# Altium schematic sheet Schematic Prints("All Documents",Physical)
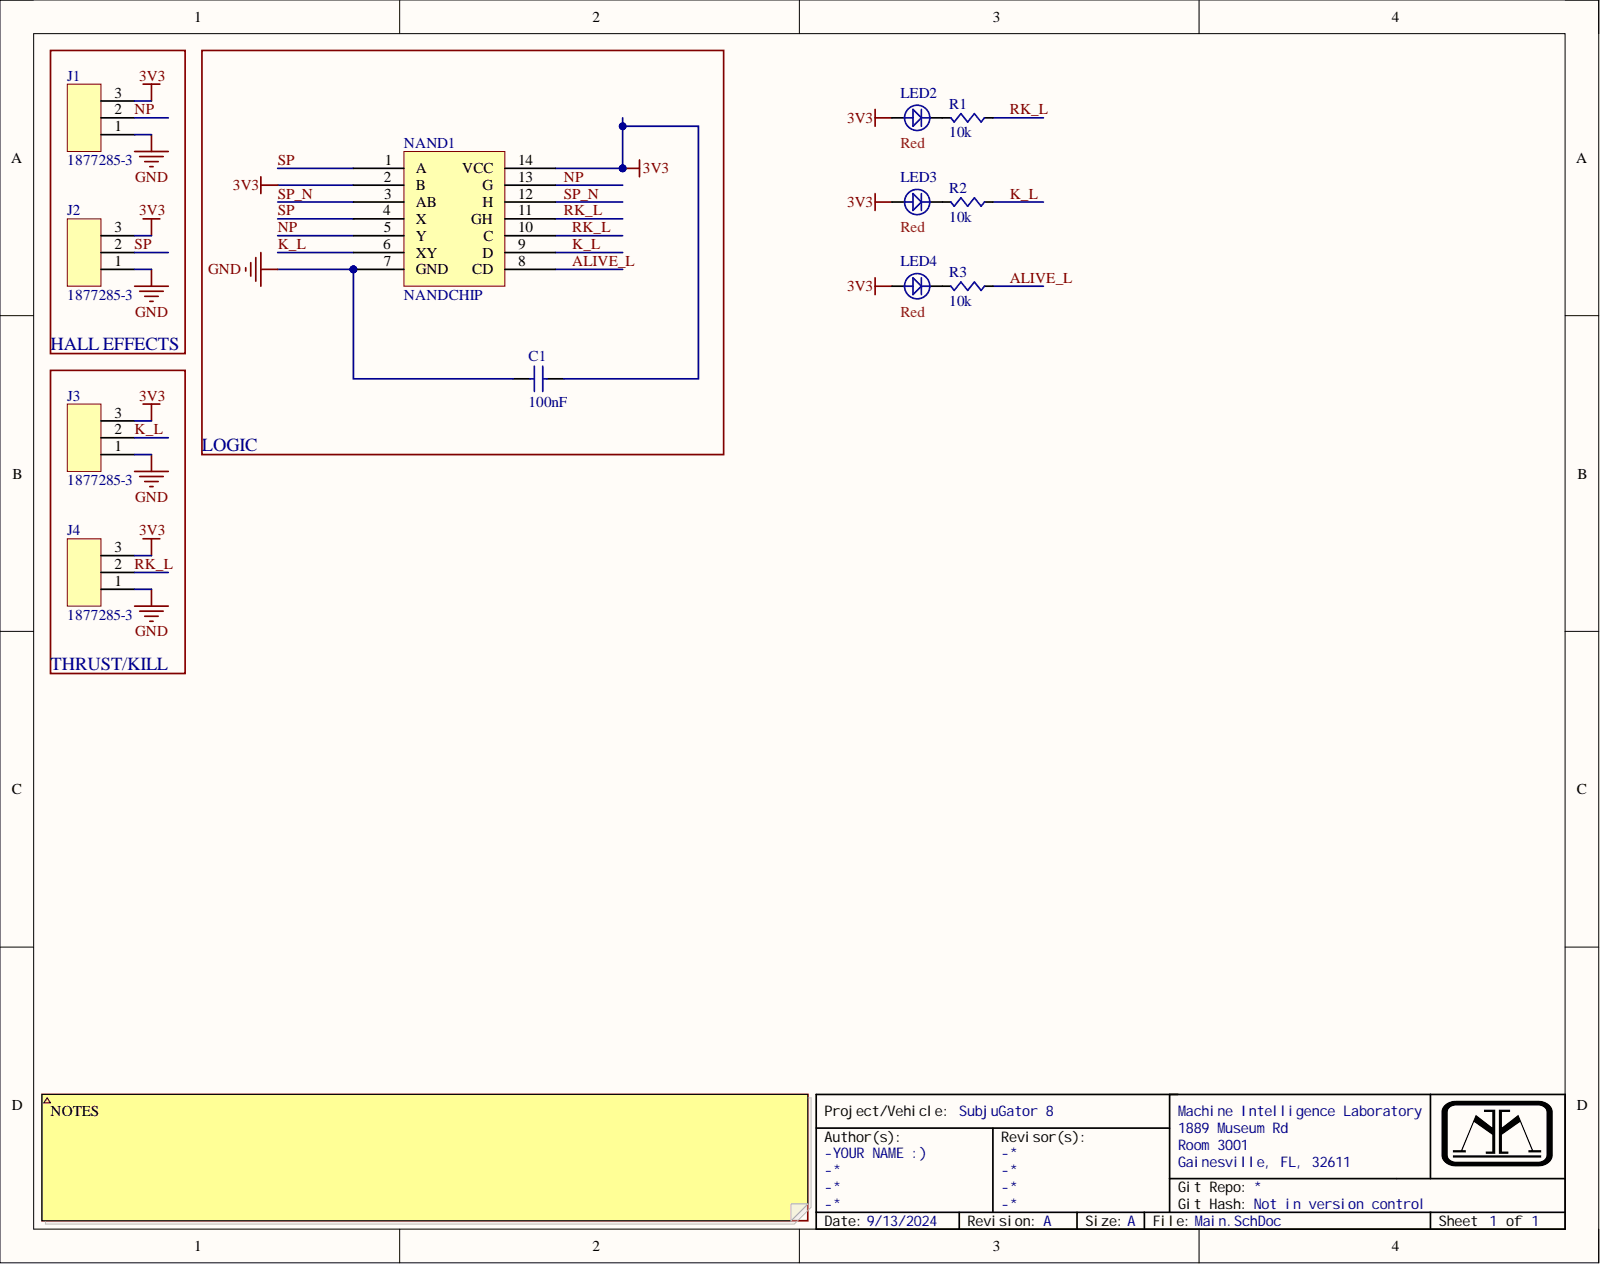
[diagram p0: Schematic Prints("All Documents",Physical)]

## Parts
C1  value 100nF  [CAPC0603]  (hidden comment: Cap)
J1  1877285-3  [1877285-3]
J2  1877285-3  [1877285-3]
J3  1877285-3  [1877285-3]
J4  1877285-3  [1877285-3]
LED2  LED  Color: Red  [LED0603]
LED3  LED  Color: Red  [LED0603]
LED4  LED  Color: Red  [LED0603]
NAND1  NANDCHIP  [NAND2]
R1  value 10k  [RES0603]  (hidden comment: Res)
R2  value 10k  [RES0603]  (hidden comment: Res)
R3  value 10k  [RES0603]  (hidden comment: Res)

## Nets
3V3: C1.2 J1.3 J2.3 J3.3 J4.3 LED2.A LED3.A LED4.A NAND1.2(B) NAND1.14(VCC)
GND: C1.1 J1.1 J2.1 J3.1 J4.1 NAND1.7(GND)
ALIVE_L: NAND1.8(CD) R3.2
K_L: J3.2 NAND1.6(XY) NAND1.9(D) R2.2
NP: J1.2 NAND1.5(Y) NAND1.13(G)
RK_L: J4.2 NAND1.10(C) NAND1.11(GH) R1.2
SP: J2.2 NAND1.1(A) NAND1.4(X)
SP_N: NAND1.3(AB) NAND1.12(H)
NetLED2_C: LED2.C R1.1
NetLED3_C: LED3.C R2.1
NetLED4_C: LED4.C R3.1

## Library data of the parts
NAND1 = TC74AC00P(F): IC GATE NAND 4CH 2-INP 14DIP; Manufacturer: Toshiba; High Level Output Current: 24mA; Logic Function: NAND GATE; Max Supply Voltage: 5.5V; Min Supply Voltage: 2V; Number of Circuits: 4; Number of Inputs: 2; Number of Outputs: 1; Propagation Delay: 7ns; Quiescent Current: 4uA; Schmitt Trigger Input: No; Case/Package: DIP; Category: Logic Gates; Component Kind: Standard; ComponentLink1Description: https://datasheet.ciiva.com/14666/0900766b8082ef5c-14666737.pdf?src-supplier=Rs; ComponentLink1URL: https://datasheet.ciiva.com/14666/0900766b8082ef5c-14666737.pdf?src-supplier=Rs; ComponentLink2Description: https://datasheet.ciiva.com/1868/tc74ac00f-datasheet-en-20140301-1868085.pdf?src-supplier=Digikey; ComponentLink2URL: https://datasheet.ciiva.com/1868/tc74ac00f-datasheet-en-20140301-1868085.pdf?src-supplier=Digikey; ComponentLink3Description: https://datasheet.ciiva.com/15090/getdatasheetpartid-40773-15090137.pdf?src-supplier=Verical; ComponentLink3URL: https://datasheet.ciiva.com/15090/getdatasheetpartid-40773-15090137.pdf?src-supplier=Verical; Height: 3.5mm; Lead Free: Lead Free; Length: 19.25mm; Library Name: Schlib1.SchLib; Low Level Output Current: 24mA; Manufacturer Part Number: TC74AC00P(F); Max Operating Temperature: 85°C; Min Operating Temperature: -40°C; Mounting Technology: Through Hole; Number of Elements: 4; Number of Gates: 4; Packaging: Rail/Tube; Pins: 14; Pricing: 1=0.87, 10=0.525, 25=0.4348, 100=0.3322, 250=0.2816, 500=0.25044, 1000=0.22427, 2500=0.19604, 5000=0.17864 (USD); Radiation Hardening: No; RoHS: Yes; RoHS Compliant: Yes; Series: TC74AC; Stock: 1734; Supplier: Digikey; Supplier Part Number: TC74AC00PF-ND; Technology: CMOS; Turn-On Delay Time: 7ns; Width: 6.4mm
C1 = Cap: Component Kind: Standard; Library Name: Passives.SchLib; Supplier 1: Digi-Key; Supplier Part Number 1: 1276-1000-1-ND
J1-J4 = 1877285-3: Component Kind: Standard; Supplier 1: Digi-Key; Supplier Part Number 1: A99846-ND; Library Name: AltiumAssignment.SchLib
LED2-LED4 = LED: Component Kind: Standard; Library Name: Semiconductors.SchLib; Supplier 1: Digi-Key; Supplier Part Number 1: 754-1122-1-ND
R1-R3 = Res: Component Kind: Standard; Library Name: Passives.SchLib; Supplier 1: Digi-Key; Supplier Part Number 1: RMCF0603JT10K0CT-ND
